# Altium schematic sheet 007_SoCPLLPowerFuse.SchDoc(007_SoCPLLPowerFuse)
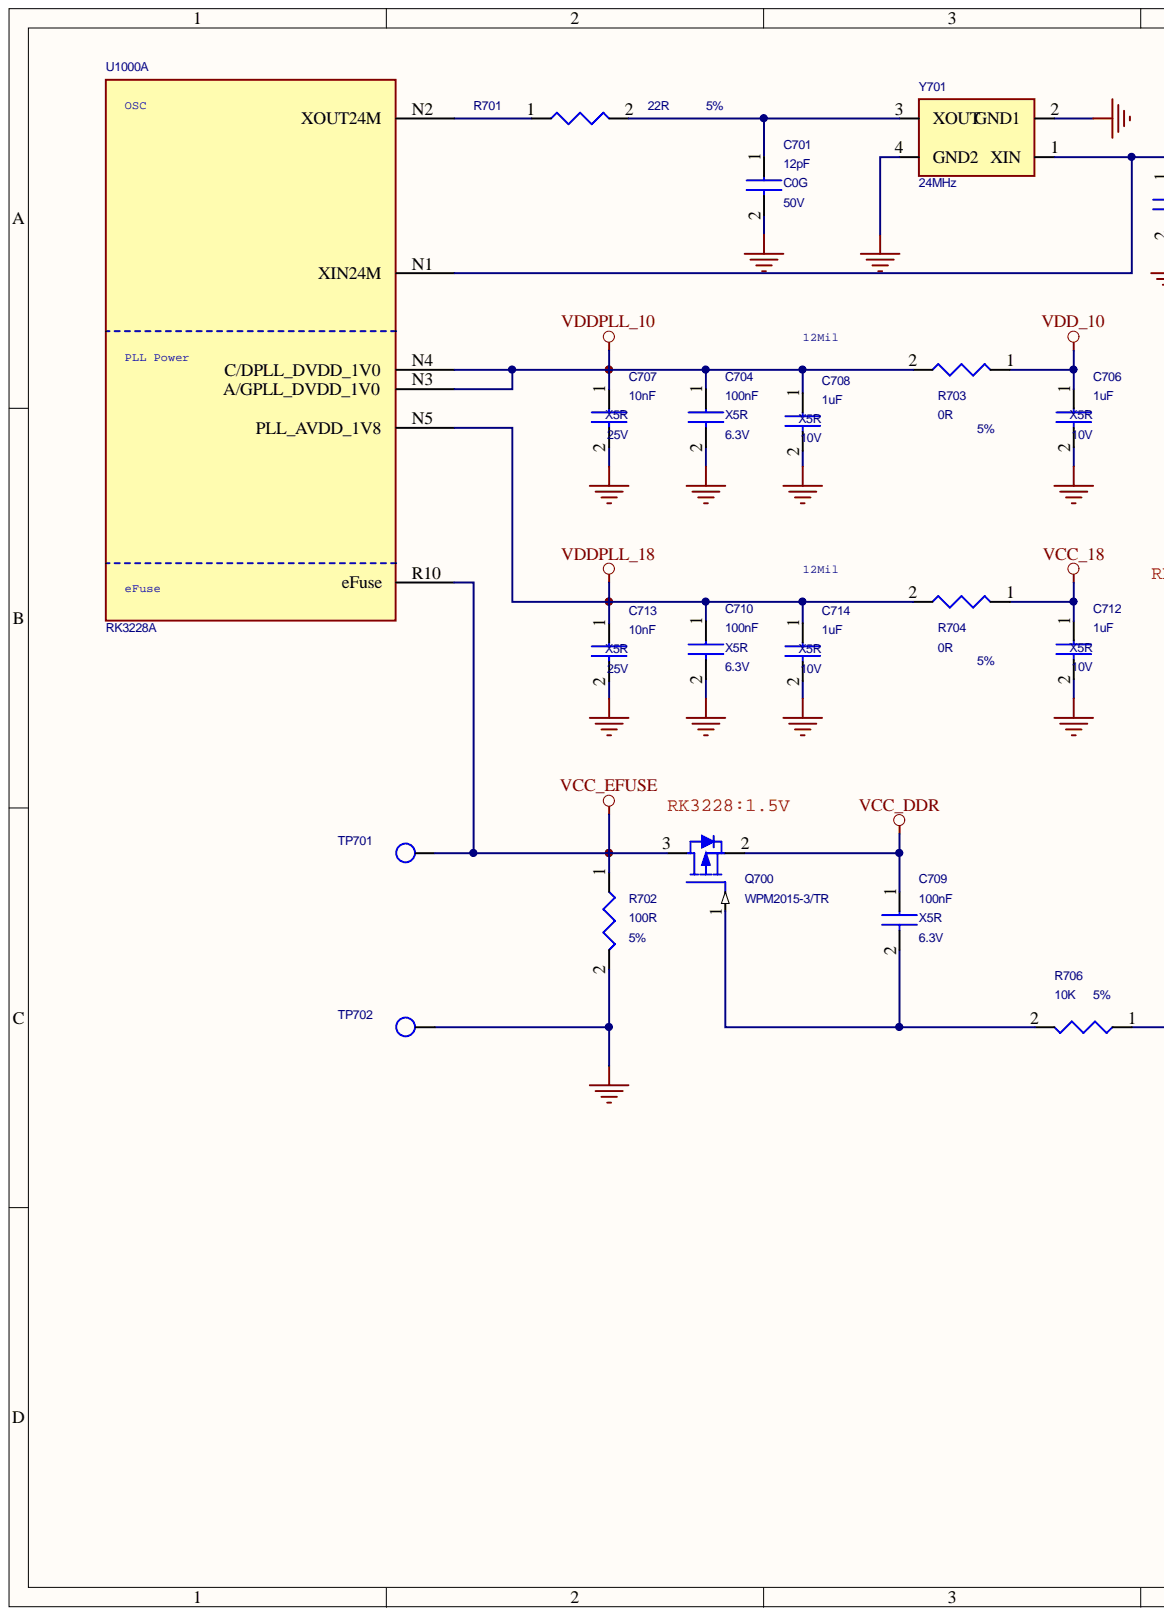
[diagram p0: 007_SoCPLLPowerFuse.SchDoc, left]
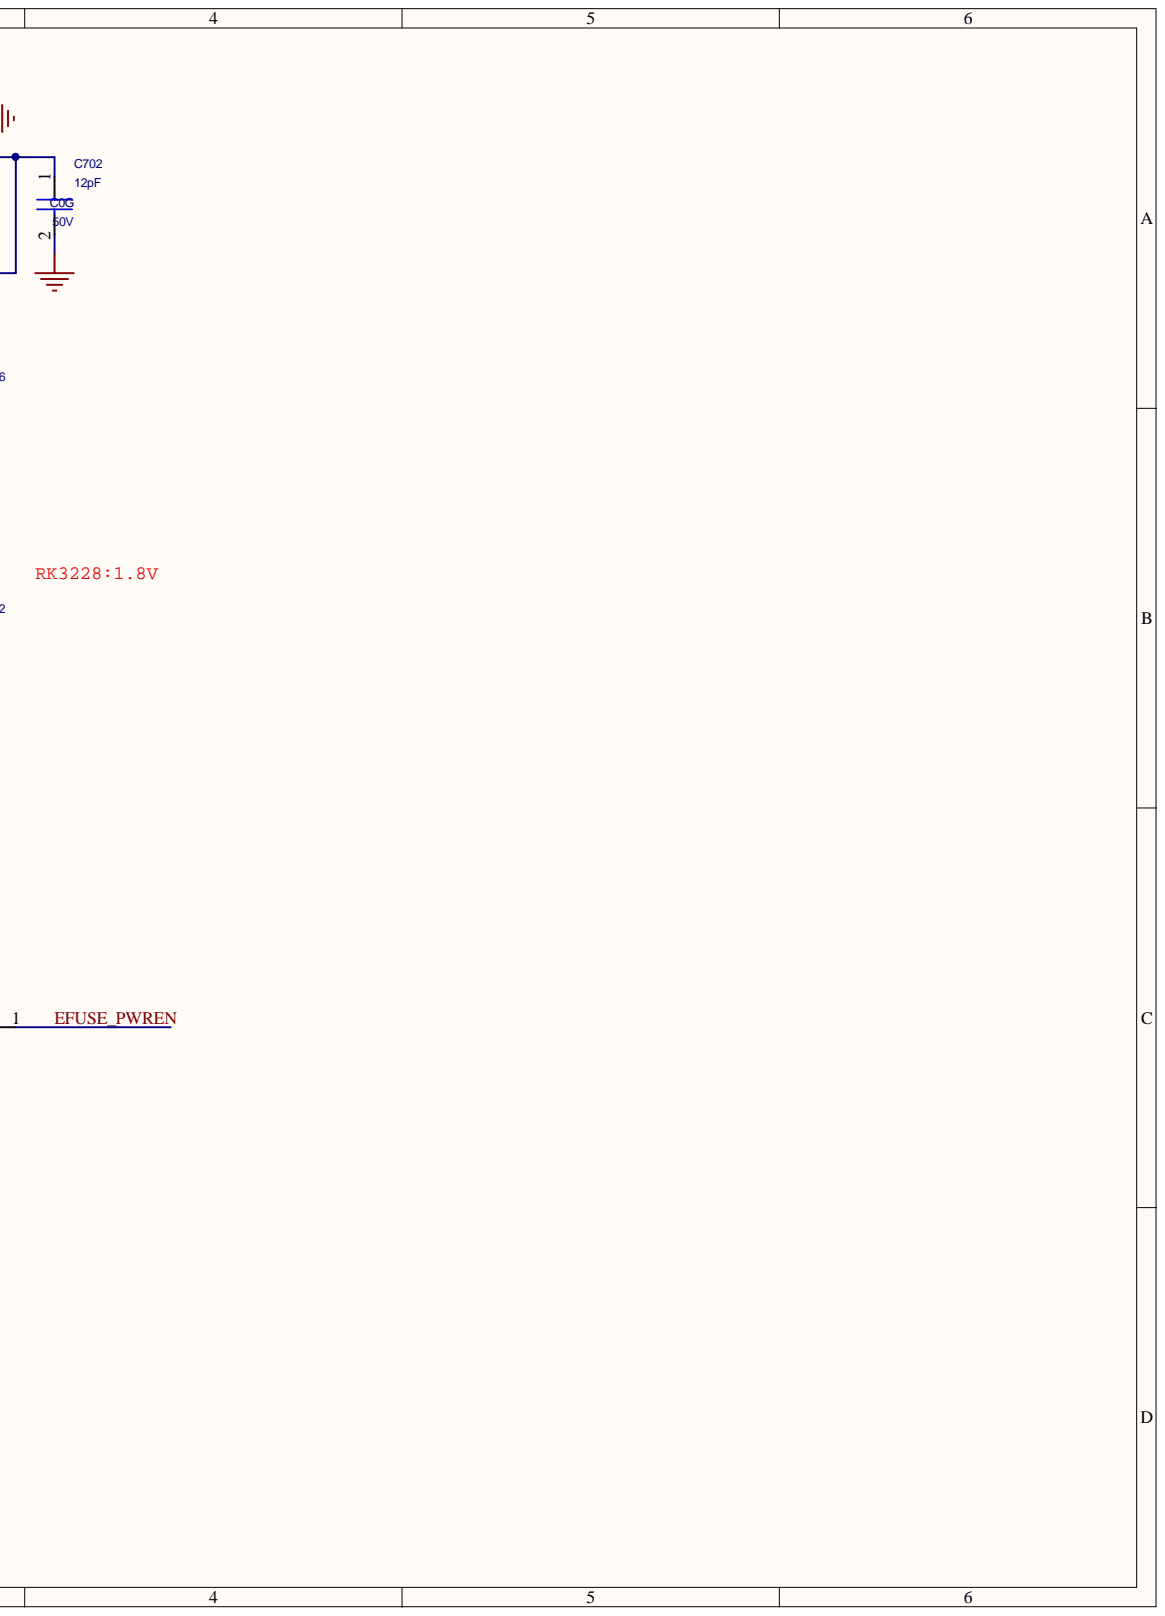
[diagram p1: 007_SoCPLLPowerFuse.SchDoc, right]

## Parts
C701  12pF  Voltage: 50V  Dielectric: C0G  [C0402]
C702  12pF  Voltage: 50V  Dielectric: C0G  [C0402]
C704  100nF  Voltage: 6.3V  Dielectric: X5R  [C0402]
C706  1uF  Voltage: 10V  Dielectric: X5R  [C0402]
C707  10nF  Voltage: 25V  Dielectric: X5R  [C0402]
C708  1uF  Voltage: 10V  Dielectric: X5R  [C0402]
C709  100nF  Voltage: 6.3V  Dielectric: X5R  [C0402]
C710  100nF  Voltage: 6.3V  Dielectric: X5R  [C0402]
C712  1uF  Voltage: 10V  Dielectric: X5R  [C0402]
C713  10nF  Voltage: 25V  Dielectric: X5R  [C0402]
C714  1uF  Voltage: 10V  Dielectric: X5R  [C0402]
Q700  WPM2015-3/TR  [SOT23]
R701  22R  [R0402]
R702  100R  Tolerance: 5%  [R0402]
R703  0R  [R0402]
R704  0R  [R0402]
R706  10K  Tolerance: 5%  [R0402]
TP701  TP_0.7  [TEST]  (value not drawn)
TP702  TP_0.7  [TEST]  (value not drawn)
U1000  RK3228A  [BGA316-14X14_0.65]  (part A)
Y701  24MHz  [CRY-3225]

## Nets
GND: C701.2 C702.2 C704.2 C706.2 C707.2 C708.2 C710.2 C712.2 C713.2 C714.2 R702.2 TP702.1 Y701.2(GND1) Y701.4(GND2)
VCC_18: C712.1 R704.1
VCC_DDR: C709.1 Q700.2
VCC_EFUSE: Q700.3 R702.1 TP701.1 U1000.R10(eFuse)
VDD_10: C706.1 R703.1
VDDPLL_10: C704.1 C707.1 C708.1 R703.2 U1000.N3(A/GPLL_DVDD_1V0) U1000.N4(C/DPLL_DVDD_1V0)
VDDPLL_18: C710.1 C713.1 C714.1 R704.2 U1000.N5(PLL_AVDD_1V8)
EFUSE_PWREN: R706.1
NetC701_1: C701.1 R701.2 Y701.3(XOUT)
NetC702_1: C702.1 U1000.N1(XIN24M) Y701.1(XIN)
NetC709_2: C709.2 Q700.1 R706.2
NetR701_1: R701.1 U1000.N2(XOUT24M)

## Library data of the parts
C701 C702 = CAP NP_Dup5: cap,12.00pF,+/-5%,50V,C0G,C0402; Manufacturer: TDK; Tolerance: 5%; RK PN: C1005C0G1H120J050BA; Priority: A; Part Type: C0402; Manufacturer PN: C1005C0G1H120J050BA; Component Kind: Standard; C_Voltage: 50V; Created by: JJJ
C704 C709 C710 = CAP NP_Dup5: cap,100.00nF,+/-10%,6.3V,X5R,C0402; Manufacturer: TDK; Tolerance: 10%; RK PN: C1005X5R0J104K050BA; Priority: A; Number: 37; Part Type: C0402; Manufacturer PN: C1005X5R0J104K050BA; Component Kind: Standard; C_Voltage: 6.3V; Created by: JJJ
C706 C708 C712 C714 = CAP NP_Dup5: cap,1.00uF,+/-10%,10V,X5R,C0402; Manufacturer: TDK; Tolerance: 10%; RK PN: C1005X5R1A105K050BB; Priority: A; Number: 37; C_Voltage: 25V; Part Type: C0402; Manufacturer PN: C1005X5R1A105K050BB; Component Kind: Standard; Created by: JJJ
C707 C713 = CAP NP_Dup5: cap,10.00nF,+/-10%,25V,X5R,C0402; Manufacturer: TDK; RK PN: C1005X5R1E103K050BA; Priority: A; Number: 37; Tolerance: +/-10%; Part Type: C0402; Manufacturer PN: C1005X5R1E103K050BA; Component Kind: Standard; C_Voltage: 25V; Created by: JJJ
Q700 = MOSFET P_Dup1: PMOS,WPM2015-3/TR,SOT_23,Id=2.4A,Vds=20V; Manufacturer: WillSemi(甲먀군랄); Vgsth: 0.62V; Vgs: ˙8V; VDS: 20V; ROOM: CHG; Priority: A; Pd: 0.5W; Id_max: 2.4A; RK PN: WPM2015-3/TR; Part Type: PMOS; Manufacturer PN: WPM2015-3/TR; Component Kind: Standard; Created by: JJJ
R701 = RESISTOR_Dup1: 哥棨슌훡롯量,22R,+/-5%,R0402,1/16W.; Manufacturer: YAGEO; Wattage: 1/16W; Tolerance: 5%; RK PN: RC0402JR-0722RL; Priority: A; Part Type: 哥棨슌훡롯量; Manufacturer PN: RC0402JR-0722RL; Component Kind: Standard; Created by: YTL
R702 = RESISTOR_Dup1: 哥棨슌훡롯量,100R,+/-5%,R0402,1/16W.; Manufacturer: YAGEO; Wattage: 1/16W; RK PN: RC0402JR-07100RL; Priority: A; Number: 177; Tolence: 5%; Part Type: 哥棨슌훡롯量; Manufacturer PN: RC0402JR-07100RL; Component Kind: Standard; Created by: YTL
R703 R704 = RESISTOR_Dup1: 哥棨슌훡롯量,0R,+/-5%,R0402,1/16W.; Manufacturer: YAGEO; Wattage: 1/16W; Tolerance: 5%; RK PN: RC0402JR-070RL; Priority: A; Part Type: 哥棨슌훡롯量; Manufacturer PN: RC0402JR-070RL; Component Kind: Standard; Created by: YTL
R706 = RESISTOR_Dup1: 哥棨슌훡롯量,10K,+/-5%,R0402,1/16W.; Manufacturer: YAGEO; Wattage: 1/16W; RK PN: RC0402JR-0710KL; Priority: A; Part Type: 哥棨슌훡롯量; Manufacturer PN: RC0402JR-0710KL; Component Kind: Standard; Created by: YTL
TP701 TP702 = TP: Test Point 0.7mm; RK PN: TESTPONIT_0R7; Priority: A; Part Type: TP; Created Date: 20140617; Component Kind: Standard; Created by: CZD
U1000 = DSP_RK3228: ROCKCHIP CPU; Manufacturer: Rockchip (쐉拱匣); RK PN: RK3228; Priority: A; Part Type: DSP; Manufacturer PN: RK3228; Component Kind: Standard; Created by: JJJ
Y701 = CRYSTAL_4P: 乞胱춥導?24M?3225,˙10ppm?8pF?Hosonic; Manufacturer: Hosonic(숨僑롯朗); RK PN: E3SB24.0000F08E11; Priority: A; Part Type: CRYSTAL; Manufacturer PN: E3SB24.0000F08E11; Component Kind: Standard; Created by: JJJ
